# Altium schematic sheet Extra0_arduino.SchDoc
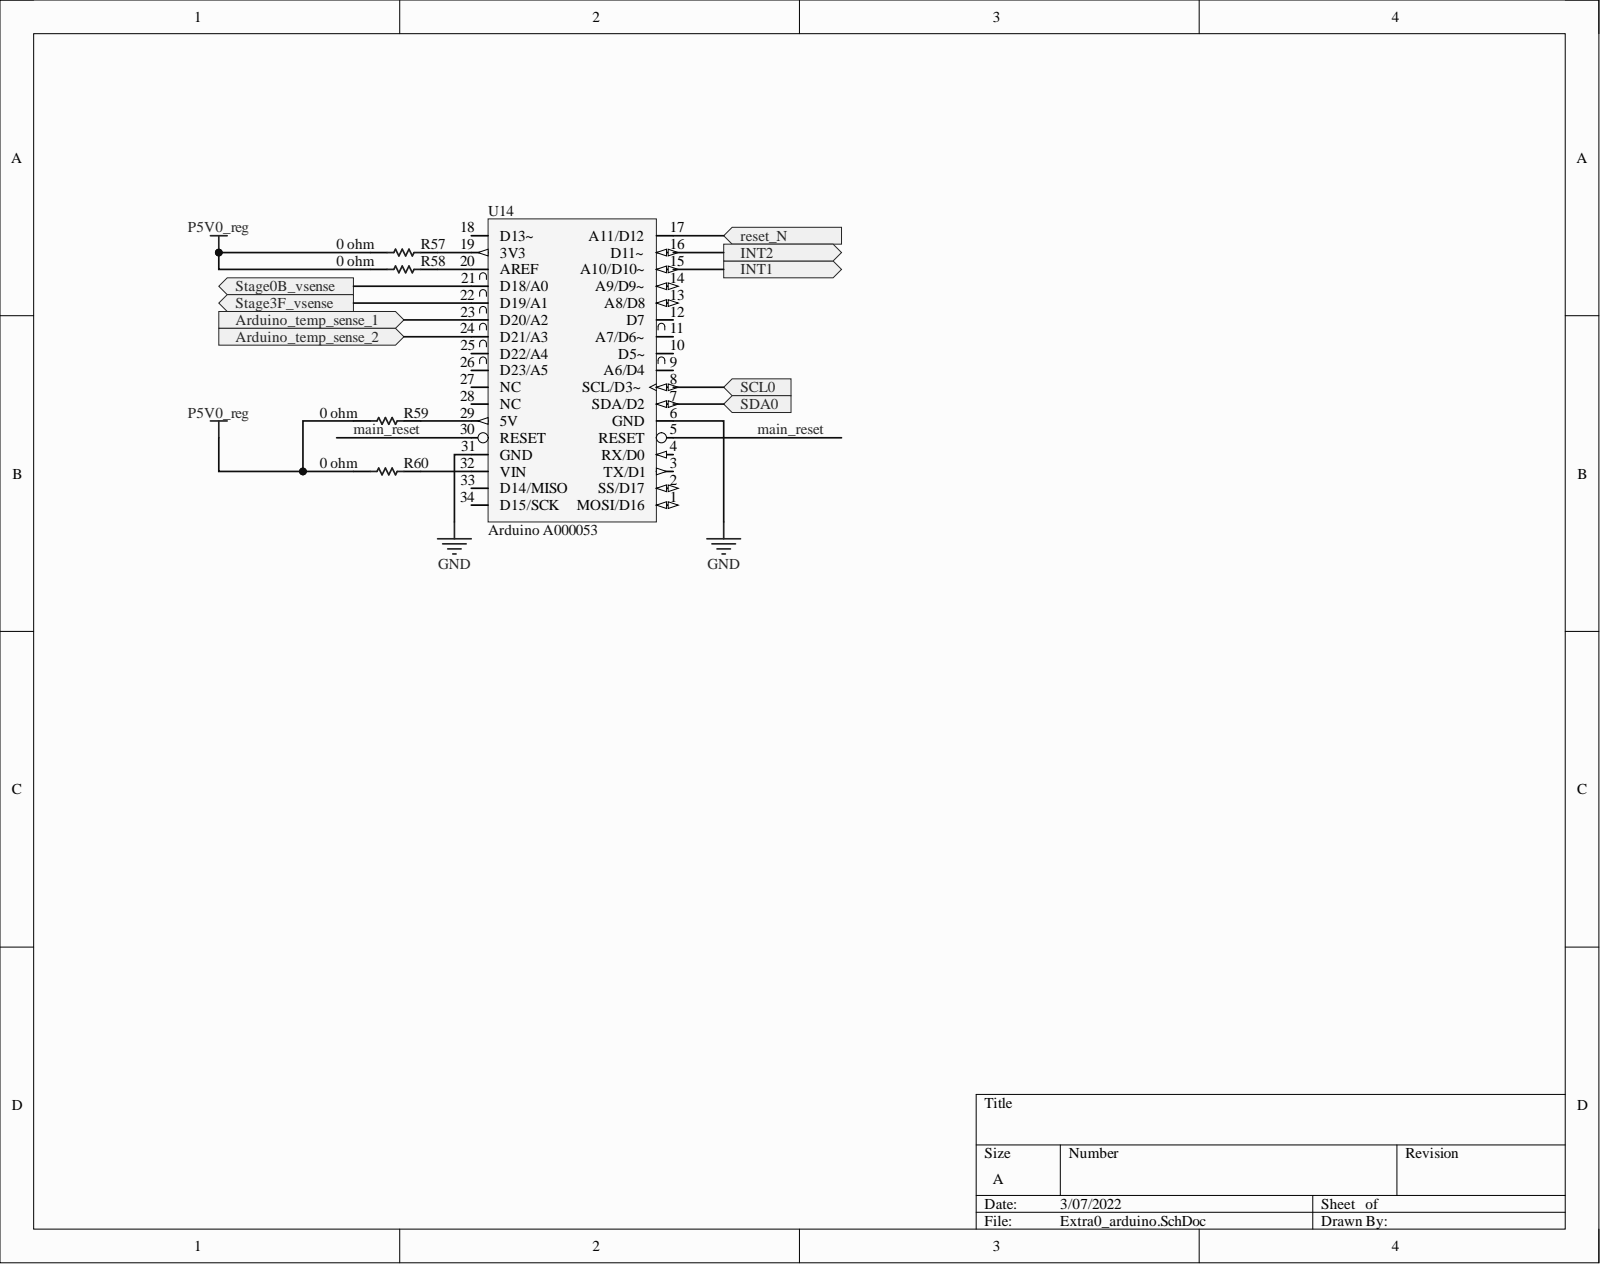
[diagram p0: Extra0_arduino.SchDoc]

## Parts
R57  0 ohm  [RESC2013X60X35NL10T20]
R58  0 ohm  [RESC2013X60X35NL10T20]
R59  0 ohm  [RESC2013X60X35NL10T20]
R60  0 ohm  [RESC2013X60X35NL10T20]
U14  Arduino A000053  Manufacturer: Arduino  Manufacturer Part Number: A000053  [Pandauino Narrow]

## Ports
Arduino_temp_sense_1, Arduino_temp_sense_2, INT1, INT2, reset_N, SCL0, SDA0, Stage0B_vsense, Stage3F_vsense

## Nets
GND: U14.6(GND) U14.31(GND)
P5V0_reg: R57.1 R58.1 R59.1 R60.1
Arduino_temp_sense_1: U14.23(D20/A2) ; port Arduino_temp_sense_1
Arduino_temp_sense_2: U14.24(D21/A3) ; port Arduino_temp_sense_2
INT1: U14.15(A10/D10~) ; port INT1
INT2: U14.16(D11~) ; port INT2
main_reset: U14.5(RESET) U14.30(RESET)
reset_N: U14.17(A11/D12) ; port reset_N
SCL0: U14.8(SCL/D3~) ; port SCL0
SDA0: U14.7(SDA/D2) ; port SDA0
Stage0B_vsense: U14.21(D18/A0) ; port Stage0B_vsense
Stage3F_vsense: U14.22(D19/A1) ; port Stage3F_vsense
NetR57_2: R57.2 U14.19(3V3)
NetR58_2: R58.2 U14.20(AREF)
NetR59_2: R59.2 U14.29(5V)
NetR60_2: R60.2 U14.32(VIN)

Not connected: U14 1(MOSI/D16) 2(SS/D17) 3(TX/D1) 4(RX/D0) 9(A6/D4) 10(D5~) 11(A7/D6~) 12(D7) 13(A8/D8) 14(A9/D9~) 18(D13~) 25(D22/A4) 26(D23/A5) 27(NC) 28(NC) 33(D14/MISO) 34(D15/SCK)

## Library data of the parts
R57-R60 = CMP-5eab975428dd816c-2: Res Thick Film 0805 0 Ohm Jumper Molded SMD T/R; Manufacturer Part Number: CRG0805ZR; Case/Package (SI): 2012; ELV Compliant: Compliant; Power Rating: 125 mW; Resistance: 0.00 Ω; Case/Package: 0805; Component Kind: Standard; Library Name: My Personal Space; Lifecycle Status: Active; Mounting Style: Surface Mount; Octopart URL: https://octopart.com/crg0805zr-te+connectivity-16077584; OctopartID: 5eab975428dd816c; Packaging: Tape & Reel (TR); RoHS: Compliant
U14 = CMP-b9da714574fd661d-14: ARDUINO MICRO ATMEGA32U4 EVAL BD; Component Kind: Standard; Library Name: My Personal Space; Utilized IC / Part: ATmega32U4
